# Altium schematic sheet USB_Interface_SCH.SchDoc
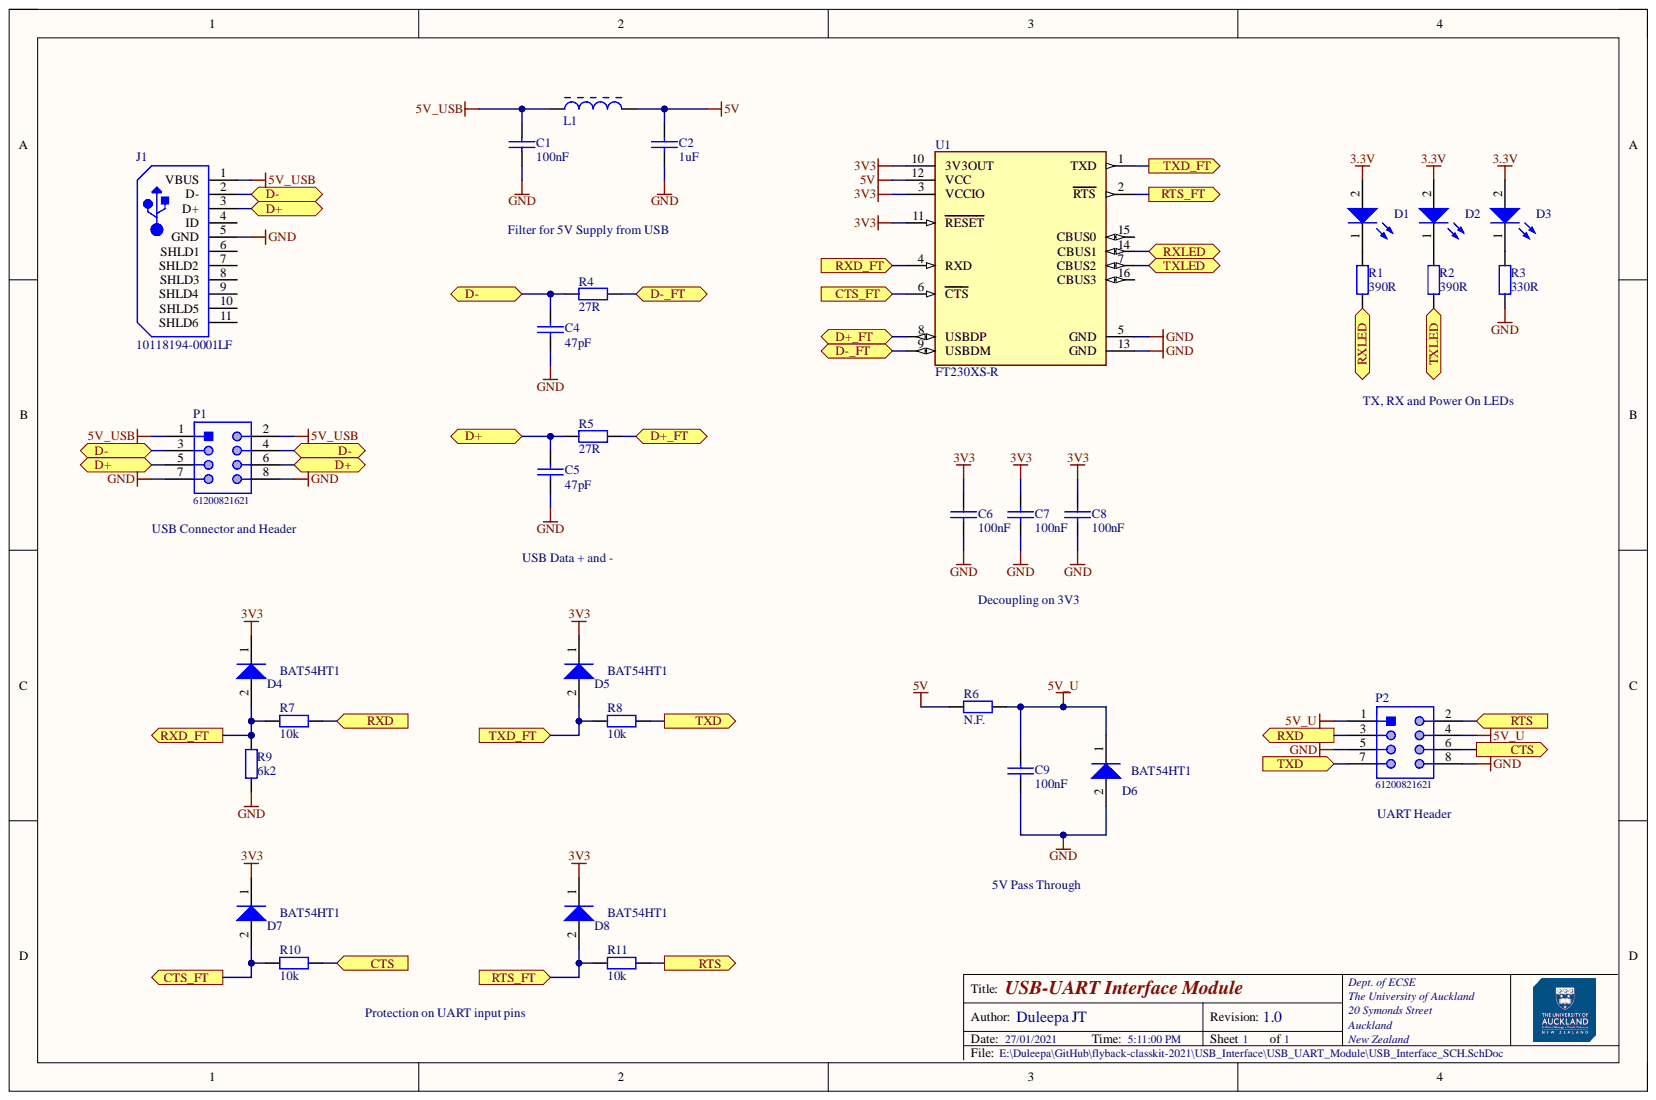
[diagram p0: USB_Interface_SCH.SchDoc]

## Parts
C1  value 100nF  [C0805]  (hidden comment: Capacitor 0805)
C2  value 1uF  [C0805]  (hidden comment: Capacitor 0805)
C4  value 47pF  [C0805]  (hidden comment: Capacitor 0805)
C5  value 47pF  [C0805]  (hidden comment: Capacitor 0805)
C6  value 100nF  [C0805]  (hidden comment: Capacitor 0805)
C7  value 100nF  [C0805]  (hidden comment: Capacitor 0805)
C8  value 100nF  [C0805]  (hidden comment: Capacitor 0805)
C9  value 100nF  [C0805]  (hidden comment: Capacitor 0805)
D1  LGR971-KN-1  [FP-LG_R971-MFG]  (value not drawn)
D2  LSR976-NR-1  [FP-LS_R976-MFG]  (value not drawn)
D3  LGR971-KN-1  [FP-LG_R971-MFG]  (value not drawn)
D4  BAT54HT1  [ONSC-SOD-323-2-477-02_V]
D5  BAT54HT1  [ONSC-SOD-323-2-477-02_V]
D6  BAT54HT1  [ONSC-SOD-323-2-477-02_V]
D7  BAT54HT1  [ONSC-SOD-323-2-477-02_V]
D8  BAT54HT1  [ONSC-SOD-323-2-477-02_V]
J1  10118194-0001LF  [FCI-10118194-0001LF-5_V]
L1  FBMH3225HM102NT  [TAYO-3225-2_V]  (value not drawn)
P1  61200821621  [61200821621]
P2  61200821621  [61200821621]
R1  value 390R  [R0805]  (hidden comment: Resistor 0805)
R2  value 390R  [R0805]  (hidden comment: Resistor 0805)
R3  value 330R  [R0805]  (hidden comment: Resistor 0805)
R4  value 27R  [R0805]  (hidden comment: Resistor 0805)
R5  value 27R  [R0805]  (hidden comment: Resistor 0805)
R6  value N.F.  [R0805]  (hidden comment: Resistor 0805)
R7  value 10k  [R0805]  (hidden comment: Resistor 0805)
R8  value 10k  [R0805]  (hidden comment: Resistor 0805)
R9  value 6k2  [R0805]  (hidden comment: Resistor 0805)
R10  value 10k  [R0805]  (hidden comment: Resistor 0805)
R11  value 10k  [R0805]  (hidden comment: Resistor 0805)
U1  FT230XS-R  [FP-SSOP-16-IPC_C]

## Ports
CTS, CTS_FT, D+, D+_FT, D-, D-_FT, RTS, RTS_FT, RXD, RXD_FT, RXLED, TXD, TXD_FT, TXLED

## Nets
3.3V: D1.2 D2.2 D3.2
3V3: C6.1 C7.1 C8.2 D4.1 D5.1 D7.1 D8.1 U1.3(VCCIO) U1.10(3V3OUT) U1.11(RESET)
5V: C2.1 L1.2 R6.1 U1.12(VCC)
5V_U: C9.2 D6.1 P2.1 P2.4 R6.2
5V_USB: C1.1 J1.1(VBUS) L1.1 P1.1 P1.2
GND: C1.2 C2.2 C4.1 C5.1 C6.2 C7.2 C8.1 C9.1 D6.2 J1.5(GND) P1.7 P1.8 P2.5 P2.8 R3.2 R9.2 U1.5(GND) U1.13(GND)
CTS: P2.6 R10.2 ; port CTS
CTS_FT: D7.2 R10.1 U1.6(CTS) ; port CTS_FT
RTS: P2.2 R11.2 ; port RTS
RTS_FT: D8.2 R11.1 U1.2(RTS) ; port RTS_FT
RXD: P2.3 R7.2 ; port RXD
RXD_FT: D4.2 R7.1 R9.1 U1.4(RXD) ; port RXD_FT
RXLED: R1.2 U1.14(CBUS1) ; port RXLED
TXD: P2.7 R8.2 ; port TXD
TXD_FT: D5.2 R8.1 U1.1(TXD) ; port TXD_FT
TXLED: R2.2 U1.7(CBUS2) ; port TXLED
NetC4_2: C4.2 J1.2(D-) P1.3 P1.4 R4.1
NetC5_2: C5.2 J1.3(D+) P1.5 P1.6 R5.1
NetD1_1: D1.1 R1.1
NetD2_1: D2.1 R2.1
NetD3_1: D3.1 R3.1
NetR4_2: R4.2 U1.9(USBDM)
NetR5_2: R5.2 U1.8(USBDP)

Not connected: J1 4(ID) 6(SHLD1) 7(SHLD2) 8(SHLD3) 9(SHLD4) 10(SHLD5) 11(SHLD6); U1 15(CBUS0) 16(CBUS3)

## Library data of the parts
C1 C2 C4-C9 = CCAP0805: Component Kind: Standard; Description: CCAP0805; Library Name: CommonDevices_V1.IntLib
D1 D3 = CMP-007-00001-2: LED Uni-Color Green 572nm 2-Pin SMD T/R; Component Kind: Standard; Library Name: ECSE@UoA
D2 = CMP-007-00000-2: LED RED DIFFUSED 0805 SMD; Component Kind: Standard; Library Name: ECSE@UoA
D4-D8 = CMP-004-00000-1: DIODE SCHOTTKY 30V 200MA SOD323; Component Kind: Standard; Library Name: ECSE@UoA
J1 = CMP-00028-00000-1: CONN USB MICRO B RECPT SMT R/A; Component Kind: Standard; Library Name: ECSE@UoA
L1 = CMP-006-00000-1: TAIYO YUDEN         FBMH3225HM102NT            Ferrite Case Style:1210 [3225 Metric]; Component Kind: Standard; Library Name: ECSE@UoA
P1 P2 = CMP-002-00000-1: CONN HEADER VERT 8POS 2.54MM; Component Kind: Standard; Library Name: ECSE@UoA
R1-R11 = RES0805: Component Kind: Standard; Description: RES0805; Library Name: CommonDevices_V1.IntLib
U1 = CMP-015-00001-2: USB-to-UART 1-CH 512byte FIFO 5V 16-Pin SSOP T/R; Component Kind: Standard; Library Name: ECSE@UoA
